# Altium schematic sheet USB_FS.SchDoc
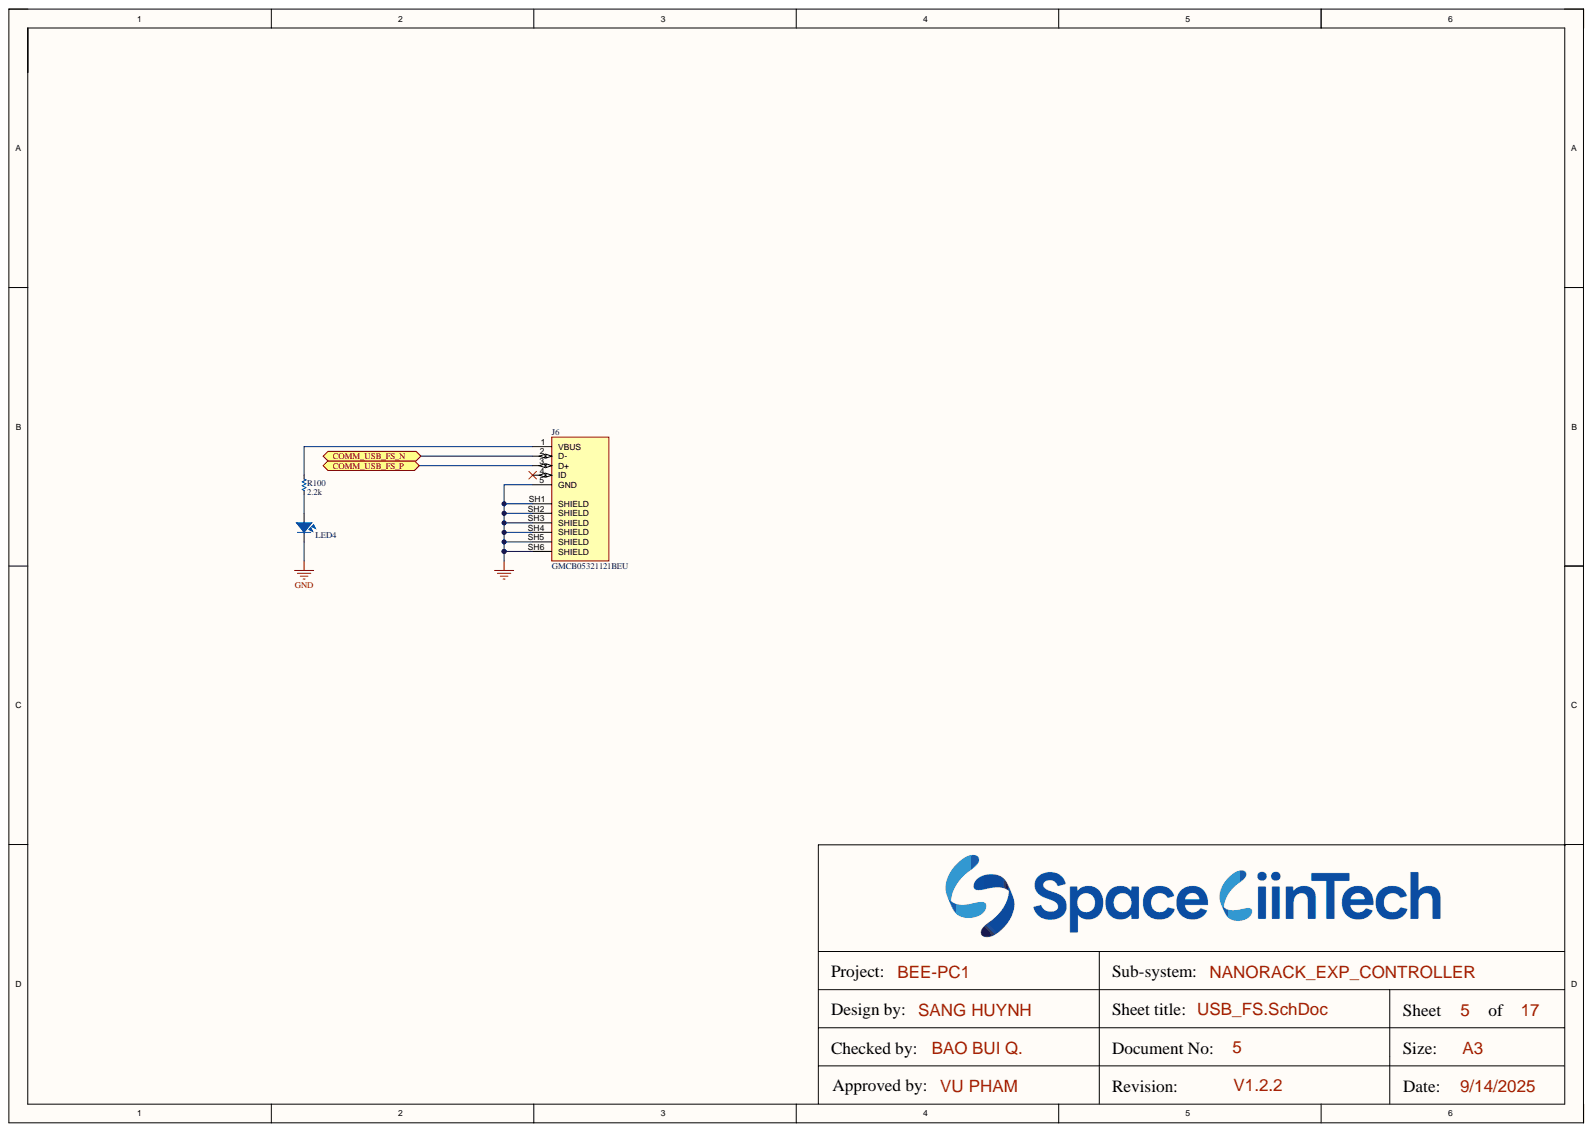
[diagram p0: USB_FS.SchDoc]

## Parts
J6  GMCB05321121BEU  [AMPHENOL_GMCB05321121BEU]
LED4  150060RS75000  [LED_0603]  (value not drawn)
R100  2.2k  [RES_C_1608X60]

## Ports
COMM_USB_FS_N, COMM_USB_FS_P

## Nets
GND: J6.5(GND) J6.SH1(SHIELD) J6.SH2(SHIELD) J6.SH3(SHIELD) J6.SH4(SHIELD) J6.SH5(SHIELD) J6.SH6(SHIELD) LED4.2
COMM_USB_FS_N: J6.2(D-) ; port COMM_USB_FS_N
COMM_USB_FS_P: J6.3(D+) ; port COMM_USB_FS_P
NetJ6_1: J6.1(VBUS) R100.2
NetLED4_1: LED4.1 R100.1

Not connected: J6 4(ID)

## Library data of the parts
J6 = GMCB05321121BEU: Micro USB 2.0 Type B Receptacle Right Angle SMT, Tape and Reel Packaging; MF: Amphenol Commercial Products; Check_prices: https://www.snapeda.com/parts/GMCB05321121BEU/Amphenol/view-part/?ref=eda; Component Kind: Standard; Library Name: COMM_SCHLIB.SchLib; MP: GMCB05321121BEU; Package: None; SnapEDA_Link: https://www.snapeda.com/parts/GMCB05321121BEU/Amphenol/view-part/?ref=snap
LED4 = LED SMD: Value: LED 3 - 5 ly 2 Colour; Component Kind: Standard; Library Name: COMM_SCHLIB.SchLib
R100 = _RES_B_2: RES; Component Kind: Standard; Library Name: LABLIB.SchLib
